# Altium schematic sheet GT_16u2_Rev2.SchDoc(GT_16u2_Rev2)
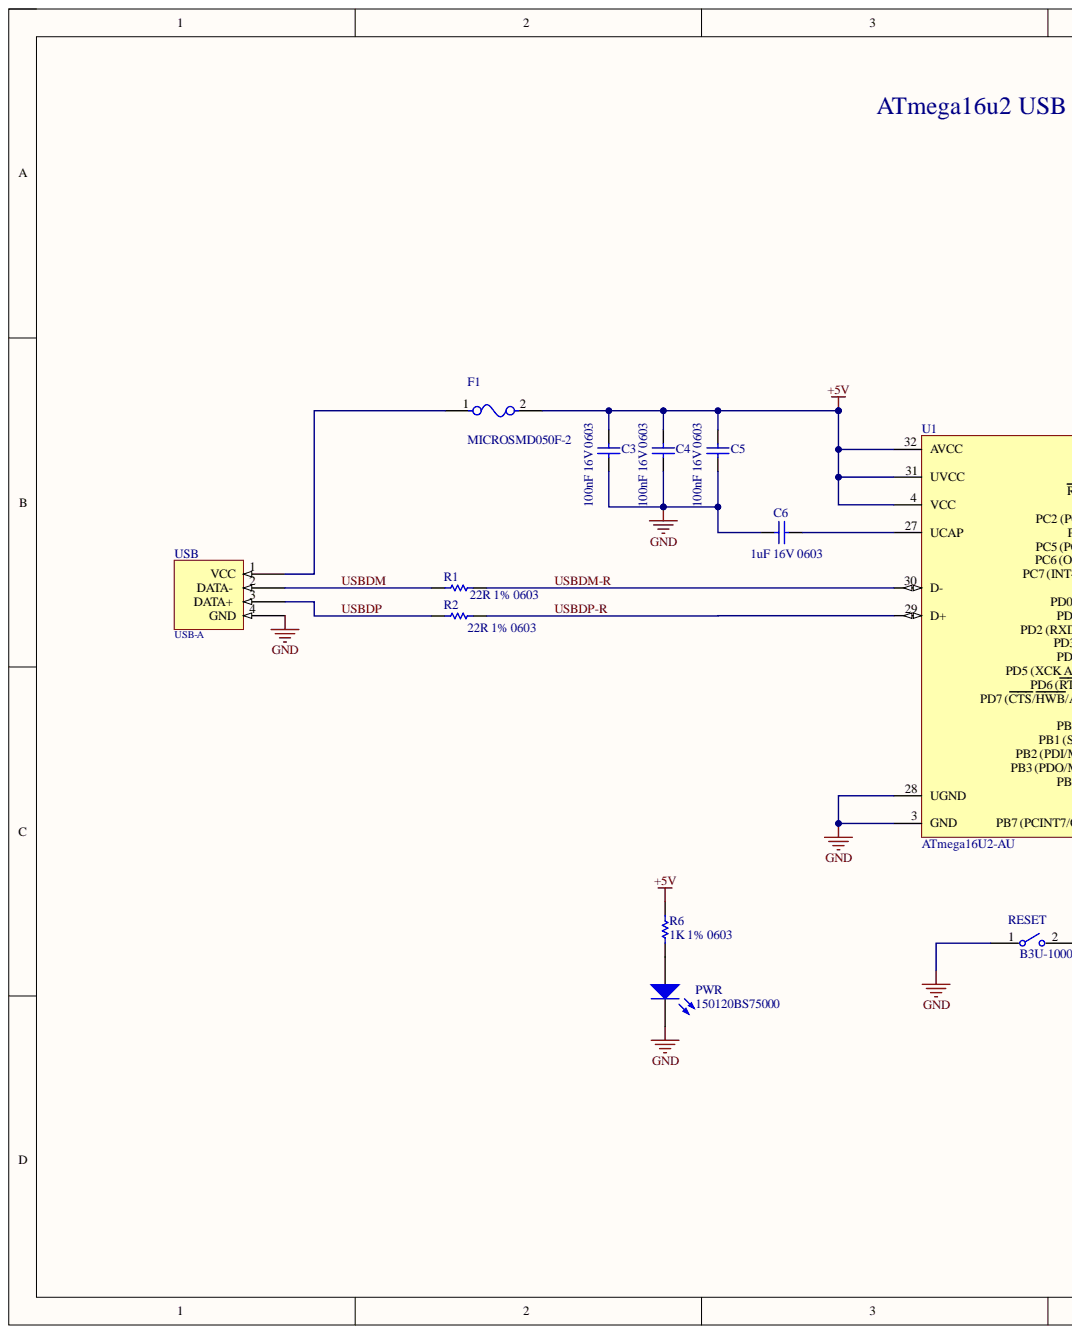
[diagram p0: GT_16u2_Rev2.SchDoc, left]
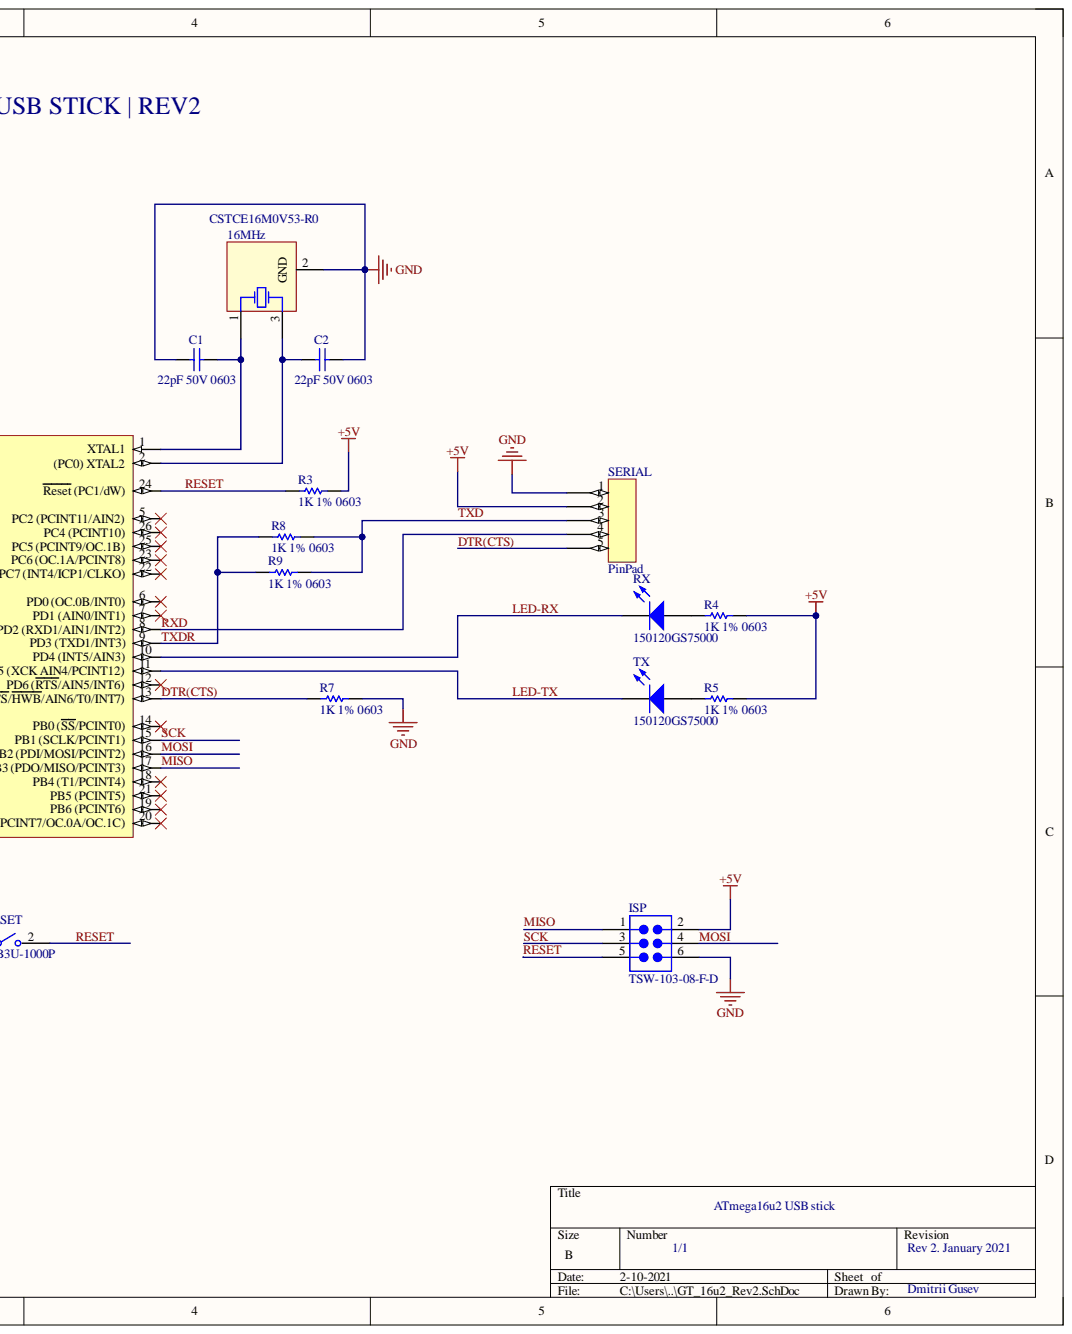
[diagram p1: GT_16u2_Rev2.SchDoc, right]

## Parts
16MHz  CSTCE16M0V53-R0  [MURA-CSTCE_V-3_V]
C1  22pF 50V 0603  PackageReference: 0603  [CAPC1608X90X40ML10T20]
C2  22pF 50V 0603  PackageReference: 0603  [CAPC1608X90X40ML10T20]
C3  100nF 16V 0603  [CAPC1608X90X35ML15T15]
C4  100nF 16V 0603  [CAPC1608X90X35ML15T15]
C5  100nF 16V 0603  [CAPC1608X90X35ML15T15]
C6  1uF 16V 0603  [CAPC1608X90X35LL15T15]
F1  MICROSMD050F-2  [FP-microSMD-MFG]
ISP  TSW-103-08-F-D  [SMTC-TSW-103-08-X-D]
PWR  150120BS75000  [1206_A]
R1  22R 1% 0603  PackageReference: 0603  [RESC1608X55X25NL10T15]
R2  22R 1% 0603  PackageReference: 0603  [RESC1608X55X25NL10T15]
R3  1K 1% 0603  Case-EIA: 0603  [RESC0603(1608)_L]
R4  1K 1% 0603  Case-EIA: 0603  [RESC0603(1608)_L]
R5  1K 1% 0603  Case-EIA: 0603  [RESC0603(1608)_L]
R6  1K 1% 0603  [RESC0603(1608)_L]
R7  1K 1% 0603  Case-EIA: 0603  [RESC0603(1608)_L]
R8  1K 1% 0603  Case-EIA: 0603  [RESC0603(1608)_L]
R9  1K 1% 0603  Case-EIA: 0603  [RESC0603(1608)_L]
RESET  B3U-1000P  [FP-B3U-1000P-MFG]
RX  150120GS75000  [1206_A]
SERIAL  PinPad  [PinPad]
TX  150120GS75000  [1206_A]
U1  ATmega16U2-AU  [32A_M]
USB  USB-A  [USB A]

## Nets
+5V: C3.2 C4.2 C5.2 F1.2 ISP.2 R3.2 R4.2 R5.2 R6.2 SERIAL.2 U1.4(VCC) U1.31(UVCC) U1.32(AVCC)
GND: 16MHz.2 C1.2 C2.1 C3.1 C4.1 C5.1 C6.1 ISP.6 PWR.1 R7.1 RESET.1 SERIAL.1 U1.3(GND) U1.28(UGND) USB.4(GND)
DTR(CTS): R7.2 SERIAL.5 U1.13(PD7_(CTS/HWB/AIN6/T0/INT7))
LED-RX: RX.1 U1.10(PD4_(INT5/AIN3))
LED-TX: TX.1 U1.11(PD5_(XCK_AIN4/PCINT12))
MISO: ISP.1 U1.17(PB3_(PDO/MISO/PCINT3))
MOSI: ISP.4 U1.16(PB2_(PDI/MOSI/PCINT2))
RESET: ISP.5 R3.1 RESET.2 U1.24(Reset_(PC1/dW))
RXD: SERIAL.4 U1.8(PD2_(RXD1/AIN1/INT2))
SCK: ISP.3 U1.15(PB1_(SCLK/PCINT1))
TXD: R8.2 R9.2 SERIAL.3
TXDR: R8.1 R9.1 U1.9(PD3_(TXD1/INT3))
USBDM: R1.1 USB.2(DATA-)
USBDM-R: R1.2 U1.30(D-)
USBDP: R2.1 USB.3(DATA+)
USBDP-R: R2.2 U1.29(D+)
Net16MHz_1: 16MHz.1 C1.1 U1.1(XTAL1)
Net16MHz_3: 16MHz.3 C2.2 U1.2((PC0)_XTAL2)
NetC6_2: C6.2 U1.27(UCAP)
NetF1_1: F1.1 USB.1(VCC)
NetPWR_2: PWR.2 R6.1
NetR4_1: R4.1 RX.2
NetR5_1: R5.1 TX.2

Not connected: U1 5(PC2_(PCINT11/AIN2)) 6(PD0_(OC.0B/INT0)) 7(PD1_(AIN0/INT1)) 12(PD6_(RTS/AIN5/INT6)) 14(PB0_(SS/PCINT0)) 18(PB4_(T1/PCINT4)) 19(PB6_(PCINT6)) 20 21(PB5_(PCINT5)) 22(PC7_(INT4/ICP1/CLKO)) 23(PC6_(OC.1A/PCINT8)) 25(PC5_(PCINT9/OC.1B)) 26(PC4_(PCINT10))

## Library data of the parts
16MHz = CMP-0588-00001-2: Ceramic Resonator, 16 MHz with Built-in Capacitance, Thickness Expander Mode, Initial Frequency Tolerance +/- 0.5%, Load Capacity 15 pF, -20 to 80 degC, 3.2 x 1.3 x 1 mm SMD, RoHS, Reel; Manufacturer: Murata; Load Capacity: 15 pF; Oscillating Frequency: 16 MHz; Component Kind: Standard; ComponentLink1Description: Manufacturer URL; ComponentLink1URL: http://www.murata.com/; ComponentLink2Description: Datasheet; ComponentLink2URL: http://www.murata.com/products/catalog/pdf/p16e.pdf; DatasheetVersion: Rev. P16E-22; Initial Frequency Tolerance: +/- 0.5%; Initial Tolerance: +/- 0.5%; Library Name: Altium Content Vault; Mounting Technology: Surface Mount; Operating Temperature Range: -20 to 80 degC; PackageDescription: 3-Pin SMD, Body 3.2 x 1.3 mm, Pitch 0.95 mm; PackageReference: CSTCE_V-3; Packing: Reel; PartNumber: CSTCE16M0V53-R0; RoHS: TRUE
C1 C2 = CMP-1659-00006-3: Chip Capacitor, 22 pF, +/- 5%, 50 V, -55 to 125 degC, 0603 (1608 Metric), RoHS, Tape and Reel; Component Kind: Standard; DatasheetVersion: Rev. 13, 05/2016; Length: 1.6 mm; Library Name: Altium Content Vault; Max Operating Temperature: 125 degC; Min Operating Temperature: -55 degC; Mount: Surface Mount; Mounting Technology: Surface Mount; PackageDescription: 2-Pin Surface Mount Device, Body 1.6 x 0.8 mm; RoHSCompliant: true
C3-C5 = CMP-2006-04496-1: Manufacturer: AVX Interconnect / Elco; Part Number: 0603YC104KAZ2A; Component Kind: Standard; Library Name: Altium Content Vault
C6 = CMP-2006-04532-1: Manufacturer: AVX Interconnect / Elco; Part Number: 0603YD105KAT2A; Component Kind: Standard; Library Name: Altium Content Vault
F1 = CMP-08606-000015-1: PTC RESET FUSE 13.2V 500MA 1210; Manufacturer: Littelfuse; Current Rating: 40A; Hold Current: 0.5A; Resistance: 0.25-0.9Ω; Trip Current: 1A; Trip Time: 0.05s; Value: 0.25-0.9Ω; Ambient Temperature Range High: +85°C; Ambient Temperature Range Low: -40°C; Automotive: No; Category: Fuse; Component Kind: Standard; ComponentLink2Description: Datasheet; ComponentLink2URL: https://www.littelfuse.com/~/media/electronics/datasheets/resettable_ptcs/littelfuse_ptc_microsmd_datasheet.pdf.pdf; Device Class L1: Circuit Protection; Device Class L2: PTC Resettable Fuses; Device Class L3: unset; Digikey Description: PTC RESET FUSE 13.2V 500MA 1210; Height: 0.62mm; Lead Free: Yes; Library Name: Altium Content Vault; Manufacturer Part Number: MICROSMD050F-2; Octopart: https://octopart.com/microsmd050f-2-littelfuse-74214982; Package: microSMD; RoHS: TRUE; Temperature: to +85°C; Temperature Range High: +85°C; Voltage Rating: 13.2V
ISP = CMP-1024-00337-1: Manufacturer: Samtec; Pitch: 2.54 mm; Component Kind: Standard; ComponentLink1Description: Manufacturer URL; ComponentLink1URL: http://www.samtec.com; ComponentLink2Description: Datasheet; ComponentLink2URL: http://www.samtec.com/documents/webfiles/pdf/TSW_TH.PDF; ComponentLink3Description: Package Specification; ComponentLink3URL: http://www.samtec.com/documents/webfiles/cpdf/TSW-XXX-XX-XXX-X-XX-XXX-MKT.pdf; DatasheetVersion: F-214; Library Name: Altium Content Vault; Mounting Technology: Through-hole; Orientation: Vertical; PackageDescription: .025[0.64] SQ. Post Terminal Strip Assembly; PackageReference: TSW-103-08-X-D; PackageVersion: DM, 8/1988; PartNumber: TSW-103-08-F-D; RoHS: True; Temperature Range: -55 to 125 degC
PWR = CMP-1426-00015-1: SMD mono-color Chip LED, WL-SMCW, Blue; Manufacturer: Wuerth Elektronik; Color: Blue; Component Kind: Standard; ComponentLink1Description: Manufacturer URL; ComponentLink1URL: http://www.we-online.com; ComponentLink2Description: Datasheet; ComponentLink2URL: http://katalog.we-online.com/led/datasheet/150120BS75000.pdf; Library Name: Altium Content Vault; PackageReference: 1206_A; PartNumber: 150120BS75000
R1 R2 = CMP-1659-00033-1: Chip Resistor, 22 Ohm, +/- 1%, 0.1 W, -55 to 155 degC, 0603 (1608 Metric), RoHS, Tape and Reel; Case\Package: SIP; Max Input Voltage: 1.4 V; Max Ouput Current: 8 A; Component Kind: Standard; ComponentLink1Description: Manufacturer URL; ComponentLink1URL: http://www.yageo.com/; ComponentLink2Description: Datasheet; ComponentLink2URL: http://www.yageo.com/documents/recent/PYu-RC_Group_51_RoHS_L_04.pdf; DatasheetVersion: Rev. 4, 02/2016; Height: 19.6 mm; Length: 18.5 mm; Library Name: Altium Content Vault; Max Operating Temperature: 100 degC; Min Operating Temperature: -25 degC; Mount: Through Hole; Output Current: 8 A; Package Quantity: 200; PackageDescription: 2-Pin Surface Mount Device, Body 1.6 x 0.8 mm; Packaging: Rail/Tube; Pins: 4; Radiation Hardening: No; REACH SVHC: Unknown; RoHSCompliant: true
R3-R5 R7-R9 = CMP-1012-00074-1: 1K 0.1W 5% 0603 (1608 Metric)  SMD; Case-Metric: 1608; Value: 1000.00 Ohm; Component Kind: Standard; Library Name: Altium Content Vault; Power: 0.1 W; Technology: SMT; Tolerance: 5 %
R6 = CMP-1012-00074-1: 1K 0.1W 5% 0603 (1608 Metric)  SMD; Case-EIA: 0603; Case-Metric: 1608; Value: 1000.00 Ohm; Component Kind: Standard; Library Name: Altium Content Vault; Power: 0.1 W; Technology: SMT; Tolerance: 5 %
RESET = CMP-2100-03586-2: SWITCH TACTILE SPST-NO 0.05A 12V; Actuator Length: 0.4mm; Actuator Type: Standard; Contact Current Rating: 50mA; Contact Resistance: 100mR; Electrical Life: 200000Cycles; Illumination Type: Non-Illuminated; Operating Force: 1.5N; Ratings: Dust Proof; Switch Function: Off-Mom; Switch Travel: 0.15mm; Throw Configuration: SPST; Component Kind: Standard; Contact Material: Silver; Current Rating: 50mA; Depth: 2.5mm; Height: 1.2mm; Insulation Resistance: 100MR; Lead Free: Lead Free; Length: 4mm; Library Name: Altium Content Vault; Max Current Rating: 50mA; Max Operating Temperature: 70°C; Max Voltage Rating (DC): 12V; Mechanical Life: 200000Cycles; Min Operating Temperature: -25°C; Mount: Surface Mount; Orientation: Straight; Package Quantity: 1; Packaging: Tape and Reel; Termination: SMD/SMT; Voltage Rating (DC): 12V; Weight: 0.000776oz; Width: 3mm
RX TX = CMP-1426-00014-1: SMD mono-color Chip LED, WL-SMCW, Green; Manufacturer: Wuerth Elektronik; Color: Green; Component Kind: Standard; ComponentLink1Description: Manufacturer URL; ComponentLink1URL: http://www.we-online.com; ComponentLink2Description: Datasheet; ComponentLink2URL: http://katalog.we-online.com/led/datasheet/150120GS75000.pdf; Library Name: Altium Content Vault; PackageReference: 1206_A; PartNumber: 150120GS75000
U1 = CMP-0095-00303-2: 8-bit AVR Microcontroller, 2.7-5.5V, 16KB Flash, 512 Bytes EEPROM, 512 Bytes SRAM, 32-pin TQFP, Industrial Grade (-40°C to 85°C); Code_JEDEC: MS-026-ABA; Component Kind: Standard; ComponentLink1Description: Manufacturer URL; ComponentLink1URL: http://www.atmel.com/; ComponentLink2Description: ATmega8U2/16U2/32U2 Datasheet; ComponentLink2URL: http://www.atmel.com/dyn/resources/prod_documents/doc7799.pdf; ComponentLink3Description: ATmega8U2/16U2/32U2 Summary; ComponentLink3URL: http://www.atmel.com/dyn/resources/prod_documents/7799S.pdf; DatasheetVersion: revD, Nov-2010; Library Name: Altium Content Vault; PackageDescription: 32-Lead, 7x7x1.0 mm Body, 0.8mm Lead Pitch, Thin Profile Plastic Quad Flat Package (TQFP); PackageReference: 32A; PackageVersion: revC, Oct-2010; RevisionNote: DatasheetVersion & PackageVersion updated. URLs added.
SERIAL = PinPad: Component Kind: Standard; Library Name: GT_16u2_Rev2.SchLib
USB = USB-A: Component Kind: Standard; Library Name: MSE310_PID.SchLib
